# Altium schematic sheet Peripherals.SchDoc(Peripherals)
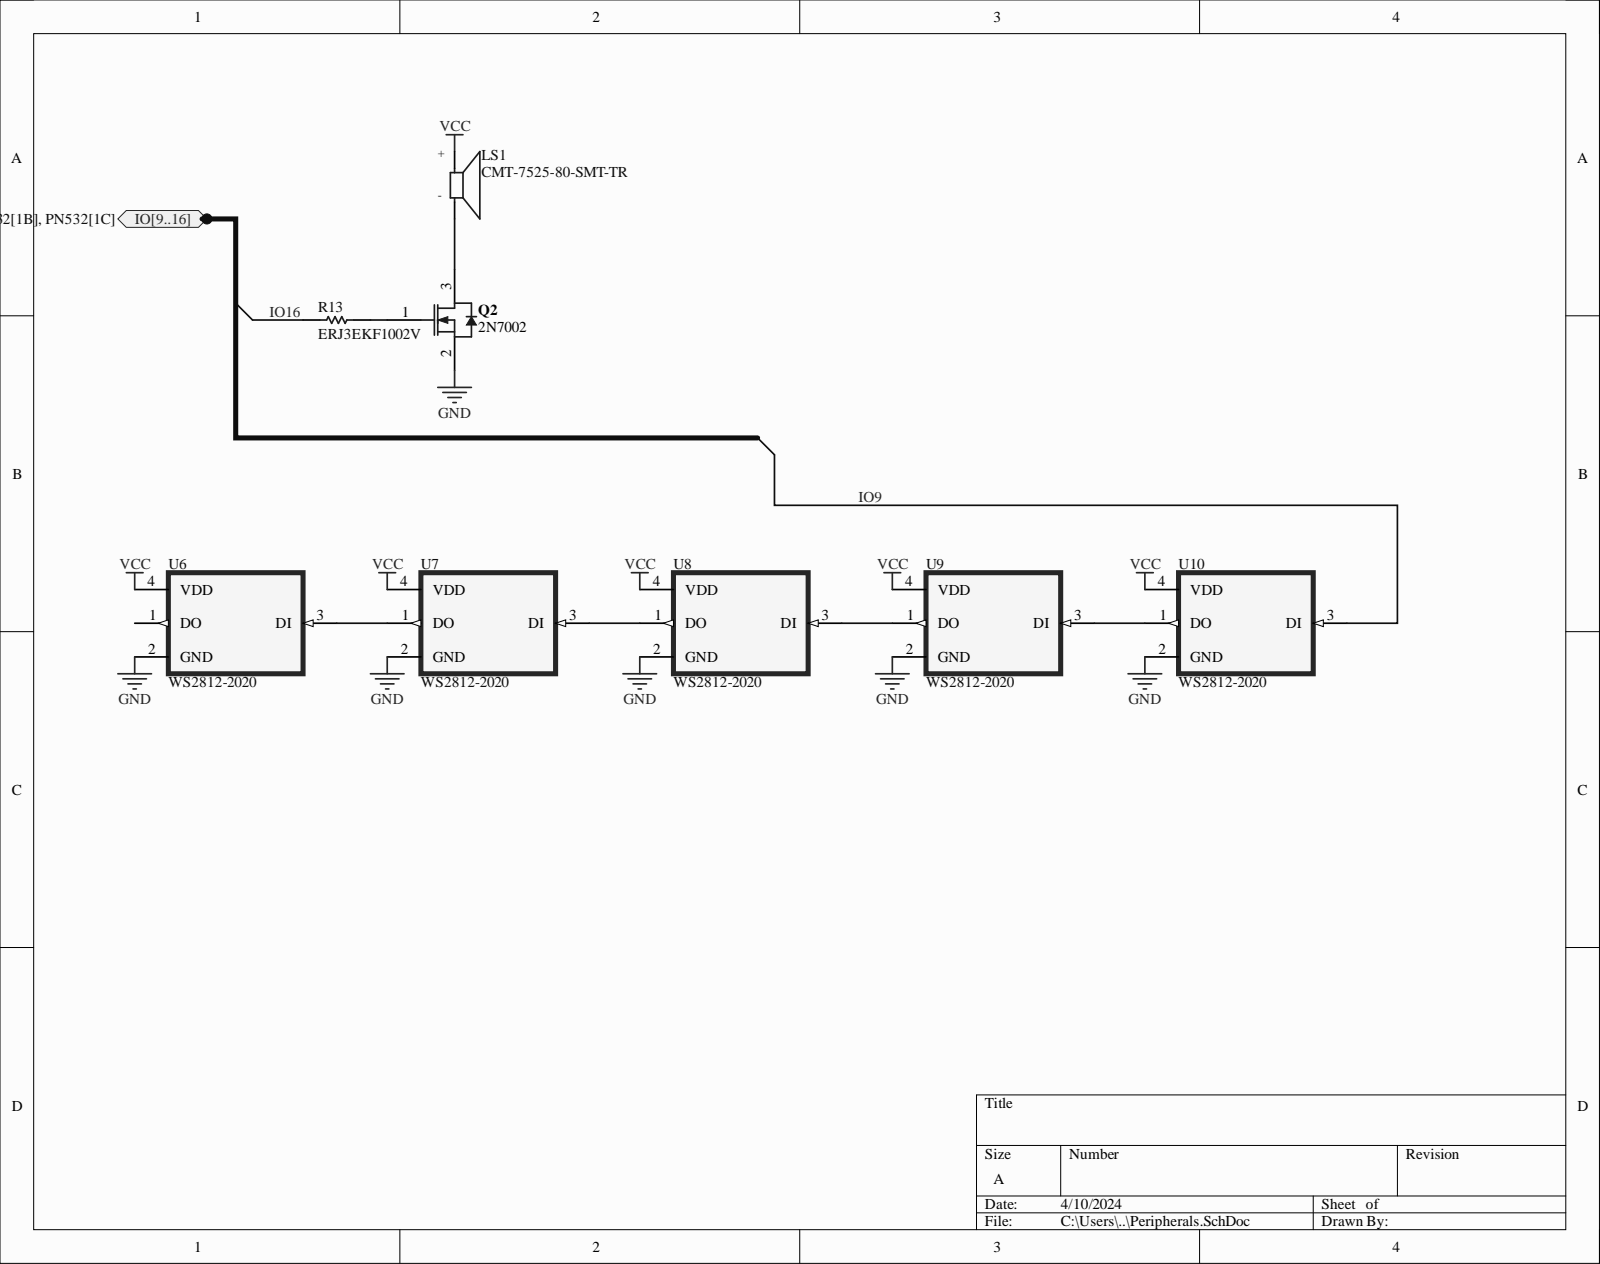
[diagram p0: Peripherals.SchDoc]

## Parts
LS1  CMT-7525-80-SMT-TR  [XDCR_CMT-7525-80-SMT-TR]
Q2  2N7002  [INF-PG-SOT23_M]
R13  ERJ3EKF1002V  [RESC1609X55X30LL15T15]
U6  WS2812-2020  [LED_WS2812-2020]
U7  WS2812-2020  [LED_WS2812-2020]
U8  WS2812-2020  [LED_WS2812-2020]
U9  WS2812-2020  [LED_WS2812-2020]
U10  WS2812-2020  [LED_WS2812-2020]

## Ports
IO9, IO10, IO11, IO12, IO13, IO14, IO15, IO16, IO[9..16]

## Nets
GND: Q2.2 U6.2(GND) U7.2(GND) U8.2(GND) U9.2(GND) U10.2(GND)
VCC: LS1.P U6.4(VDD) U7.4(VDD) U8.4(VDD) U9.4(VDD) U10.4(VDD)
IO9: U10.3(DI) ; port IO[9..16]  [also labelled ]
IO16: R13.1 ; port IO[9..16]  [also labelled ]
NetLS1_N: LS1.N Q2.3
NetQ2_1: Q2.1 R13.2
NetU6_3: U6.3(DI) U7.1(DO)
NetU7_3: U7.3(DI) U8.1(DO)
NetU8_3: U8.3(DI) U9.1(DO)
NetU9_3: U9.3(DI) U10.1(DO)
N00003_10: ; port IO[9..16]
N00003_11: ; port IO[9..16]
N00003_12: ; port IO[9..16]
N00003_13: ; port IO[9..16]
N00003_14: ; port IO[9..16]
N00003_15: ; port IO[9..16]

Not connected: U6 1(DO)

## Library data of the parts
LS1 = CMT-7525-80-SMT-TR: MF: {'manufacturer': u'CUI Devices'}; Availability: Unavailable; Component Kind: Standard; Description: CMT-7525-80-SMT-TR; MP: CMT-7525-80-SMT-TR; Package: None; Price: None
Q2 = CMP-1149-00026-1: N-Channel OptiMOS Small-Signal-Transistor, 60 V VDS, 0.3 A ID, -55 to 150 degC, PG-SOT23, Reel, Green; Manufacturer: Infineon; Green: TRUE; ID (max): 0.3Â A; IDpuls (max): 1.2Â A; Ptot (max): 0.5Â W; QG: 0.4Â nC; RDS (on) (max): 3,000 mOhm; RDS (on) (max) (@4.5V): 4,000 mOhm; RthJA (max): 250 K/W; VDS (max): 60 V; VGS(th) (max): 2.5Â V; VGS(th) (min): 1.5Â V; Component Kind: Standard; ComponentLink1Description: Manufacturer URL; ComponentLink1URL: http://www.infineon.com/; ComponentLink2Description: Datasheet; ComponentLink2URL: http://www.infineon.com/dgdl/2N7002_Rev2.4.pdf?folderId=db3a3043156fd573011622e10b5c1f67&fileId=db3a304319bc939a0119c2a9461e79e6; ComponentLink3Description: Package Specification; ComponentLink3URL: http://www.infineon.com/dgdl/SOT23-Package_Overview.pdf?folderId=db3a30431936bc4b0119532290053c66&fileId=db3a30431936bc4b0119533468123c85; DatasheetVersion: Rev. 2.4; Halogen-free: TRUE; Library Name: Altium Content Vault; Mounting Technology: Surface Mount; PackageDescription: 3-Pin Small Outline Transistor Package, 2.9 x 1.3 mm Body, 0.95 mm Pitch; PackageReference: PG-SOT23; PackageVersion: Apr-08; Packing: Reel; PartNumber: 2N7002
R13 = CMP-1012-00623-3: Chip Resistor, 10 KOhm, +/- 1%, 0.1 W, -55 to 155 degC, 0603 (1608 Metric), RoHS, Tape and Reel; Manufacturer: Panasonic; Case\/Package: 0603; Max Power Dissipation: 100mW; Resistance: 10KOhm; Temperature Coefficient: 100ppm\/degreesC; Voltage Rating: 75V; Case Code (Imperial): 0603; Case Code (Metric): 1608; Component Kind: Standard; Composition: ThickFilm; Contact Plating: Tin; Datasheet URL: https://industrial.panasonic.com/cdbs/www-data/pdf/RDA0000/AOA0000C304.pdf; Datasheet Version: 02/2017; Depth: 0.8mm; Height: 0.45mm; Lead Free: LeadFree; Length: 1.6mm; Library Name: Altium Content Vault; Manufacturer URL: http://www.panasonic.com/; Max Operating Temperature: 155degC; Military Standard: Not; Min Operating Temperature: -55degC; Mount: SurfaceMount; Mounting Technology: SurfaceMount; Number of Pins: 2; Number of Terminations: 2; Package Description: 2-Pin Surface Mount Device, Body 1.6 x 0.85 mm; Package Quantity: 1; Package Reference: 603; Packaging: TapeandReel; Power Rating: 100mW; Radiation Hardening: No; REACH SVHC: NoSVHC; RoHSCompliant: true; Termination: SMD/SMT; Tolerance: 1%; Voltage Rating (DC): 75V; Width: 0.031inch
U6-U10 = WS2812-2020: MF: {'manufacturer': u'Worldsemi'}; Availability: Unavailable; Component Kind: Standard; MP: WS2812-2020; Package: None; Price: None
